# Altium schematic sheet Power.SchDoc(Power)
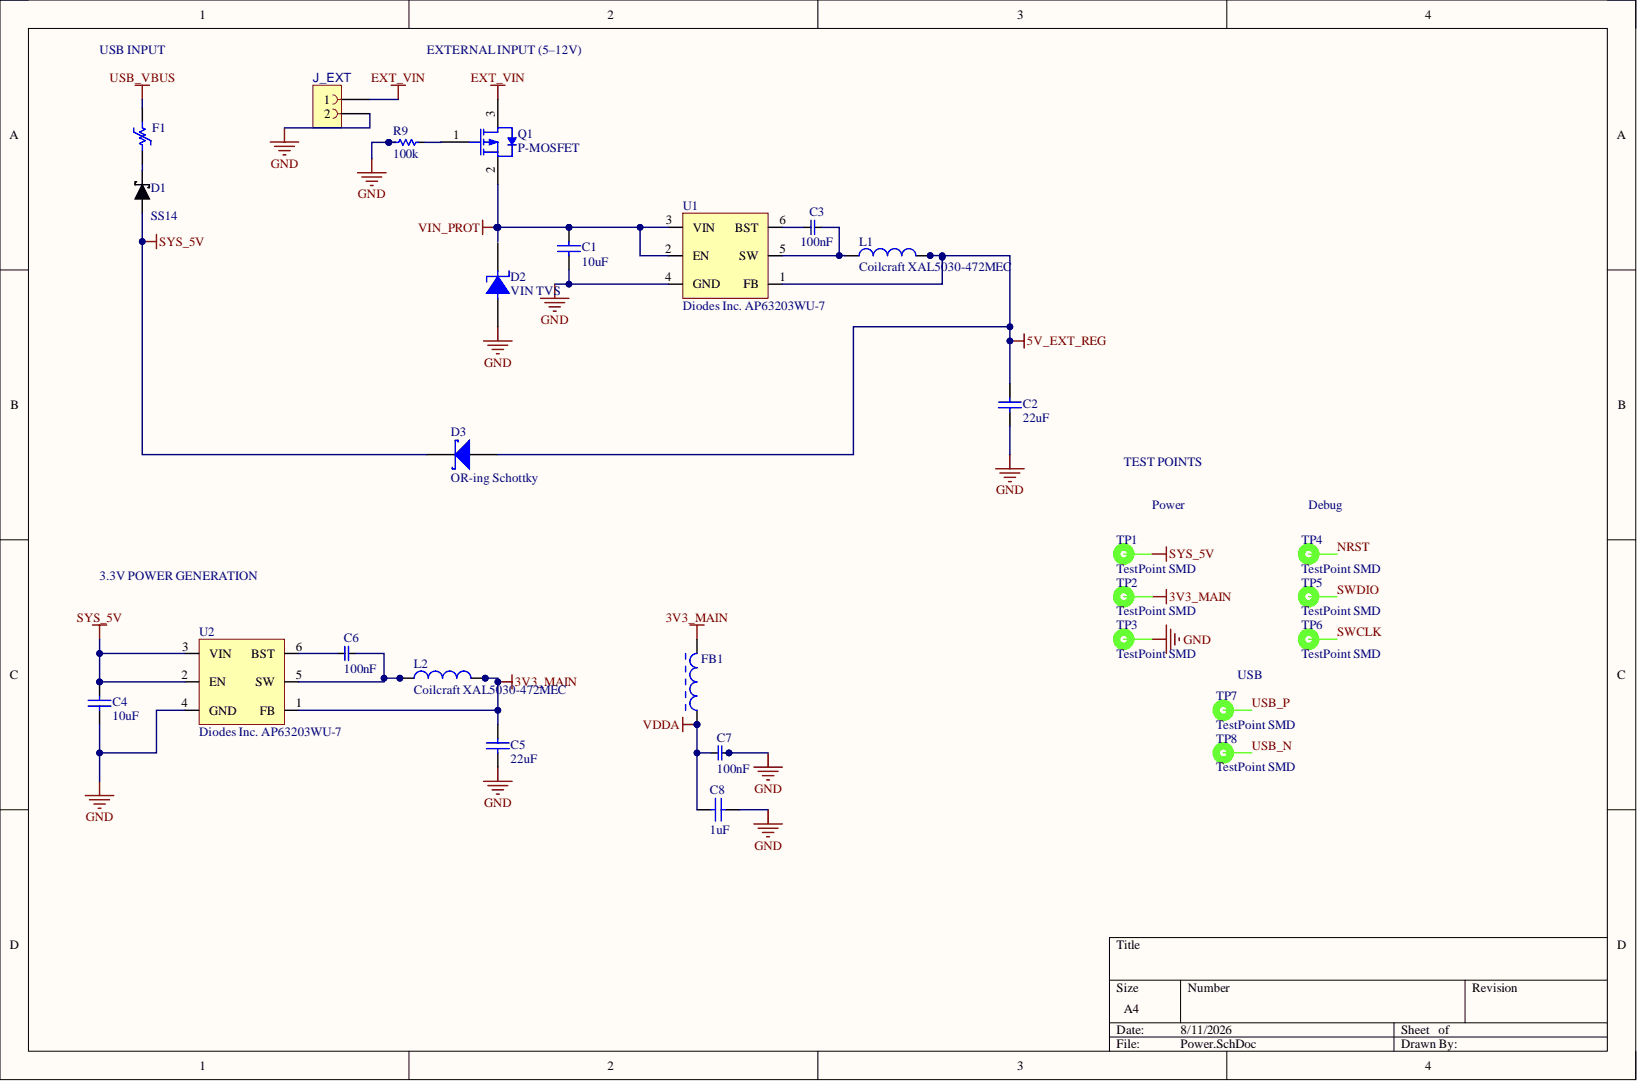
[diagram p0: Power.SchDoc]

## Parts
C1  10uF  [PCB-p4p2p2gnhi9hwenucyw6-1]
C2  22uF
C3  100nF
C4  10uF  [PCB-p4p2p2gnhi9hwenucyw6-1]
C5  22uF
C6  100nF
C7  100nF
C8  1uF  [PCB-62k5bkr5htgbt86zyguo-1]
D1  Manufacturer Part Number: SS14  (hidden comment: Fairchild Semiconductor SS14)
D2  VIN TVS  [PCB-9qq8yczch7qbe6np1bha-1]
D3  OR-ing Schottky
F1  Bourns MF-PSMF050X-2  (value not drawn)
FB1  BLM18AG601SN1D  (value not drawn)
J_EXT  Phoenix Contact 1729128  [PCB-751788-20]  (value not drawn)
L1  Coilcraft XAL5030-472MEC  Manufacturer Part Number: XAL5030-472MEC  [PCB-eh2uh0b1ckxc83nsi4qq-1]
L2  Coilcraft XAL5030-472MEC  Manufacturer Part Number: XAL5030-472MEC  [PCB-eh2uh0b1ckxc83nsi4qq-1]
Q1  P-MOSFET
R9  100k
TP1  TestPoint SMD  [PCB-vtunxdy8pwlhfr222vjc-1]
TP2  TestPoint SMD  [PCB-vtunxdy8pwlhfr222vjc-1]
TP3  TestPoint SMD  [PCB-vtunxdy8pwlhfr222vjc-1]
TP4  TestPoint SMD  [PCB-vtunxdy8pwlhfr222vjc-1]
TP5  TestPoint SMD  [PCB-vtunxdy8pwlhfr222vjc-1]
TP6  TestPoint SMD  [PCB-vtunxdy8pwlhfr222vjc-1]
TP7  TestPoint SMD  [PCB-vtunxdy8pwlhfr222vjc-1]
TP8  TestPoint SMD  [PCB-vtunxdy8pwlhfr222vjc-1]
U1  Diodes Inc. AP63203WU-7  Manufacturer Part Number: AP63203WU-7  [PCB-0002-00079-5]
U2  Diodes Inc. AP63203WU-7  Manufacturer Part Number: AP63203WU-7  [PCB-0002-00079-5]

## Nets
3V3_MAIN: C5.2 FB1.2 L2.2 TP2.1 U2.1(FB)
5V_EXT_REG: C2.2 D3.2 L1.2 U1.1(FB)
EXT_VIN: J_EXT.1 Q1.3
GND: C1.1 C2.1 C4.1 C5.1 C7.2 C8.2 D2.2 J_EXT.2 R9.1 TP3.1 U1.4(GND) U2.4(GND)
SYS_5V: C4.2 D1.2 D3.1 TP1.1 U2.2(EN) U2.3(VIN)
USB_VBUS: F1.2
VDDA: C7.1 C8.1 FB1.1
VIN_PROT: C1.2 Q1.2 U1.2(EN) U1.3(VIN)
NRST: TP4.1
SWCLK: TP6.1
SWDIO: TP5.1
USB_N: TP8.1
USB_P: TP7.1
NetC3_1: C3.1 U1.6(BST)
NetC3_2: C3.2 L1.1 U1.5(SW)
NetC6_1: C6.1 U2.6(BST)
NetC6_2: C6.2 L2.1 U2.5(SW)
NetD1_1: D1.1 F1.1
NetQ1_1: Q1.1 R9.2

Not connected: D2 1

## Library data of the parts
C1 C4 = CMP-5c4a946cea47838d-4: Cap Ceramic 10uF 25V X5R 10% SMD 0805 85°C Embossed T/R; Manufacturer Part Number: CL21A106KAYNNNE; Capacitance: 10.0 µF; Lead-Free Status: Lead Free; Voltage Rating (DC): 25.0 V; Capacitance Tolerance: ±10%; Case/Package: 0805; Component Kind: Standard; Dielectric Characteristic: X5R; Library Name: My Personal Space; Mounting Style: Surface Mount; Octopart URL: https://octopart.com/cl21a106kaynnne-samsung-19698539; OctopartID: 5c4a946cea47838d; Packaging: Tape & Reel (TR); RoHS: Compliant
C2 C5 = CMP-ef25233f913230e2-1: 0805 22uF 25V X5R 20% multilayer ceramic capacitor; Manufacturer Part Number: CL21A226MAYNNNE; Capacitance: 22.0 µF; Voltage Rating (DC): 25.0 V; Capacitance Tolerance: ±20%; Case/Package: 0805; Component Kind: Standard; Dielectric Characteristic: X5R; Library Name: My Personal Space; Octopart Url: https://octopart.com/cl21a226maynnne-samsung-84958065; OctopartID: ef25233f913230e2
C3 C6 C7 = CMP-1af8d38ac64bc53f-24: Ceramic capacitor SMD-0603 100nF 50V X7R# K +-10%, Samsung, RoHS; Manufacturer Part Number: CL10B104KB8NNNC; Capacitance Tolerance: ±10%; Dielectric Characteristic: X7R; Lead-Free Status: Lead Free; Voltage Rating (DC): 50.0 V; Capacitance: 100 nF; Case/Package: 0603; Component Kind: Standard; DATE: 2024_09_30; JLCPCB Part #: C1591; Library Name: My Personal Space; Mounting Style: Surface Mount; Octopart URL: https://octopart.com/part/samsung-electro-mechanics/CL10B104KB8NNNC; OctopartID: 1af8d38ac64bc53f; PN Digikey: 1276-1000-1-ND; PN FAB: CL10B104KB8NNNC; PN JLCPCB: C1591; Price DK: 0.1; Price JLCPCB: 0.0023; RoHS: Compliant
C8 = CMP-f7023f64287128c5-7: 1uF Ceramic capacitor SMD-0603 1uF 50V X5R# K +-10%, Samsung, RoHS; Manufacturer Part Number: CL10A105KB8NNNC; Capacitance Tolerance: ±10%; Dielectric Characteristic: X5R; Lead-Free Status: Lead Free; Voltage Rating (DC): 50.0 V; Case/Package: 0603; Component Kind: Standard; LCSC #: C15849; Library Name: My Personal Space; Mounting Style: Surface Mount; Octopart URL: https://octopart.com/cl10a105kb8nnnc-samsung-23919125; OctopartID: f7023f64287128c5; Operating Temperature: -55.0 °C (min); Packaging: Tape & Reel (TR); RoHS: Compliant; Supplier: LCSC; Supplier Part Number: C15849; Value: 1uF
D1 = CMP-814764-5: DIODE SCHOTTKY 40V 1A SMA; Current Rating: 1.0 A; Lead-Free Status: Lead Free; Polarity: Standard; Voltage Rating (DC): 40.0 V; Case/Package: DO-214; Component Kind: Standard; Library Name: My Personal Space; Lifecycle Status: Not Listed by Manufacturer; Mounting Style: Surface Mount; Number of Pins: 2; Octopart Url: https://octopart.com/ss14-fairchild+semiconductor-55390225; OctopartID: ce2a046ddb5d11ed; REACH SVHC Compliance: No SVHC; RoHS: Compliant
D2 = CMP-f10c38c5a08b2ecb-7: Diode; 30.2 A (Max.); 13.30 V (Min.) @ 1 mA; 5 uA (Max.) @ 12 V; 100 A (Max.); Manufacturer Part Number: SMBJ12A; Breakdown Voltage: 13.3 V; Lead-Free Status: Lead Free; Operating Voltage: 12.0 V; Power Dissipation: 5.00 W; Power Rating: 600 W; Case/Package: DO-214; Component Kind: Standard; Library Name: My Personal Space; Lifecycle Status: Active; Mounting Style: Surface Mount; Number of Pins: 2; Octopart URL: http://octopart.com/smbj12a-bourns-12809441; OctopartID: f10c38c5a08b2ecb; Packaging: Cut Tape (CT); REACH SVHC Compliance: No SVHC; RoHS: Compliant; Size-Height: 2.44 mm; Size-Length: 5.59 mm; Size-Width: 3.94 mm; Voltage Rating (DC): 12.0 V
D3 = CMP-63ffae44396ebc38-2: PMEG4010AESB - 40 V, 1 A low VF MEGA Schottky barrier rectifier; Manufacturer Part Number: PMEG4010AESBYL; Lead-Free Status: Lead Free; Component Kind: Standard; Library Name: My Personal Space; Lifecycle Status: Active; Mounting Style: Surface Mount; Octopart Url: https://octopart.com/pmeg4010aesbyl-nexperia-78741495; OctopartID: 63ffae44396ebc38; Operating Temperature: 150 °C (max); Packaging: Cut Tape (CT); RoHS: Compliant
F1 = CMP-277780-4: FUSE PTC RESETTABLE SMD 0805; Holding Current: 0.5 A; Operating Voltage: 6.0 V; Power Dissipation: 0.5 W; Time to Trip: 0.1 s; Tripping Current: 1.0 A; Component Kind: Standard; Library Name: My Personal Space; Manufacturer Part Number: MF-PSMF050X-2; Mounting Style: Surface Mount; Octopart Url: https://octopart.com/mf-psmf050x-2-bourns-7483438; OctopartID: 87d74eaf929f5810; REACH SVHC Compliance: No SVHC; RoHS: Compliant; Voltage Rating (DC): 6.0 V
FB1 = CMP-14419471-5: Chip Ferrite Bead for General Use, 600 Ohm, 500 mA, -55 to 125 degC, 1.6 x 0.8 x 0.95 mm SMD, Tape and Reel; Current Rating: 0.2 A; Frequency: 100000000.0 Hz; Lead-Free Status: Lead Free; Number of Circuits: 1; Resistance: 600.0 Ω; Shielding: No; Case/Package: 0603; Component Kind: Standard; Library Name: My Personal Space; Manufacturer Part Number: BLM18AG601SN1D; Mounting Style: Surface Mount; Number of Pins: 2; Octopart Url: https://octopart.com/blm18ag601sn1d-murata-42207; OctopartID: 9cb755bd1d0e4370; REACH SVHC Compliance: No SVHC; RoHS: Compliant; Size-Height: 0.0008 m; Size-Length: 0.0016 m; Size-Width: 0.0008 m
J_EXT = CMP-751788-39: SERVAL Bornera negra 2 vias; Current Rating: 13.5 A; Lead-Free Status: Lead Free; Number of Contacts: 2; Pin Pitch: 5.08 mm; Voltage Rating (AC): 250 V; Wire Gauge: 16.0 AWG to 26.0 AWG; Color: Green; Component Kind: Standard; Flammability Rating: UL 94 V-0; Housing Color: Green; Housing Material: Nylon; Insulation Material: Nylon; Library Name: My Personal Space; Manufacturer Part Number: 1729128; Mounting Style: Through Hole, PC Board, Screw, PCB; Number of Positions: 2; Number of Rows: 1; Octopart URL: https://octopart.com/1729128-phoenix+contact-4366; OctopartID: e955205fdd3d3692; Packaging: Bulk; REACH SVHC Compliance: No SVHC; RoHS: Compliant; Size-Height: 10.0 mm; Size-Length: 10.2 mm; Size-Width: 8.10 mm
L1 L2 = CMP-6f03a7253721b0c4-1: Power inductor, shielded, 20% tol, SMT, RoHS, halogen f; Inductance: 4.70 µH; Lead-Free Status: Contains Lead; Self Resonant Frequency: 23.0 MHz; Component Kind: Standard; Library Name: My Personal Space; Lifecycle Status: Active; Mounting Style: Surface Mount; Octopart URL: https://octopart.com/xal5030-472mec-coilcraft-19692868; OctopartID: 6f03a7253721b0c4; Packaging: Tape & Reel (TR), Cut Tape (CT); REACH SVHC Compliance: No SVHC; RoHS: Compliant
Q1 = CMP-19304379-2: Power MOSFET, -20 V, -1 A, P-Channel, 3-Pin SOT-23, Pb-Free, Tape and Reel; Manufacturer Part Number: NTR1P02T1G; Current Rating: -1.0 A; Drain to Source Resistance (on) (Rds): 0.148 Ω; Drain to Source Voltage (Vds): -20.0 V; Lead-Free Status: Lead Free; Power Dissipation: 0.4 W; Rise Time: 9e-09 s; Case/Package: SOT-23; Component Kind: Standard; Library Name: My Personal Space; Lifecycle Status: Active; Mounting Style: Surface Mount; Number of Pins: 3; Octopart Url: https://octopart.com/ntr1p02t1g-on+semiconductor-341573; OctopartID: a43d6d32b71e7bfa; Polarity: P-Channel; REACH SVHC Compliance: No SVHC; RoHS: Compliant; Voltage Rating (DC): -20.0 V
R9 = CMP-9abf51c576ad4578-32: 100 kOhms ±1% 0.1W, 1/10W Chip Resistor 0603 (1608 Metric) Moisture Resistant Thick Film; Manufacturer Part Number: RC0603FR-07100KL; Lead-Free Status: Lead Free; Resistance: 100 kΩ; Voltage Rating (DC): 75 V; Component Kind: Standard; JLCPCB Part #: C14675; Library Name: My Personal Space; Mounting Style: Surface Mount; Number of Pins: 2; Octopart Url: https://octopart.com/part/yageo-group/RC0603FR-07100KL; OctopartID: 9abf51c576ad4578; Package / Case: 0603 (1608 Metric); Power Rating: 0.1 W; REACH SVHC Compliance: No SVHC; Resistance Tolerance: ±1%; RoHS: Compliant; Seller Landing  Url: https://octopart-clicks.com/click/track?country=US&ak=8157f166&sig=0120b0c&sid=2402&ppid=40946036&vpid=115424056&ct=offers; Size-Height: 0.00045 m; Size-Length: 0.0016 m; Size-Width: 0.0008 m
TP1-TP8 = CMP-0dc8c4c22640d20f-23: Test Point; Micro Mini; SMT; Component Kind: Standard; Library Name: My Personal Space
U1 U2 = CMP-0ef5c227cacdd132-7: AP63203 Series 3.3 V 2 A SMT Fixed Low IQ Synchronous Buck - TSOT-26; Component Kind: Standard; Library Name: My Personal Space; Lifecycle Status: Not Listed by Manufacturer; Octopart URL: https://octopart.com/ap63203wu-7-diodes+inc.-98099550; OctopartID: 0ef5c227cacdd132
